# Altium schematic sheet CW308T_PSoC62_UFO.SchDoc
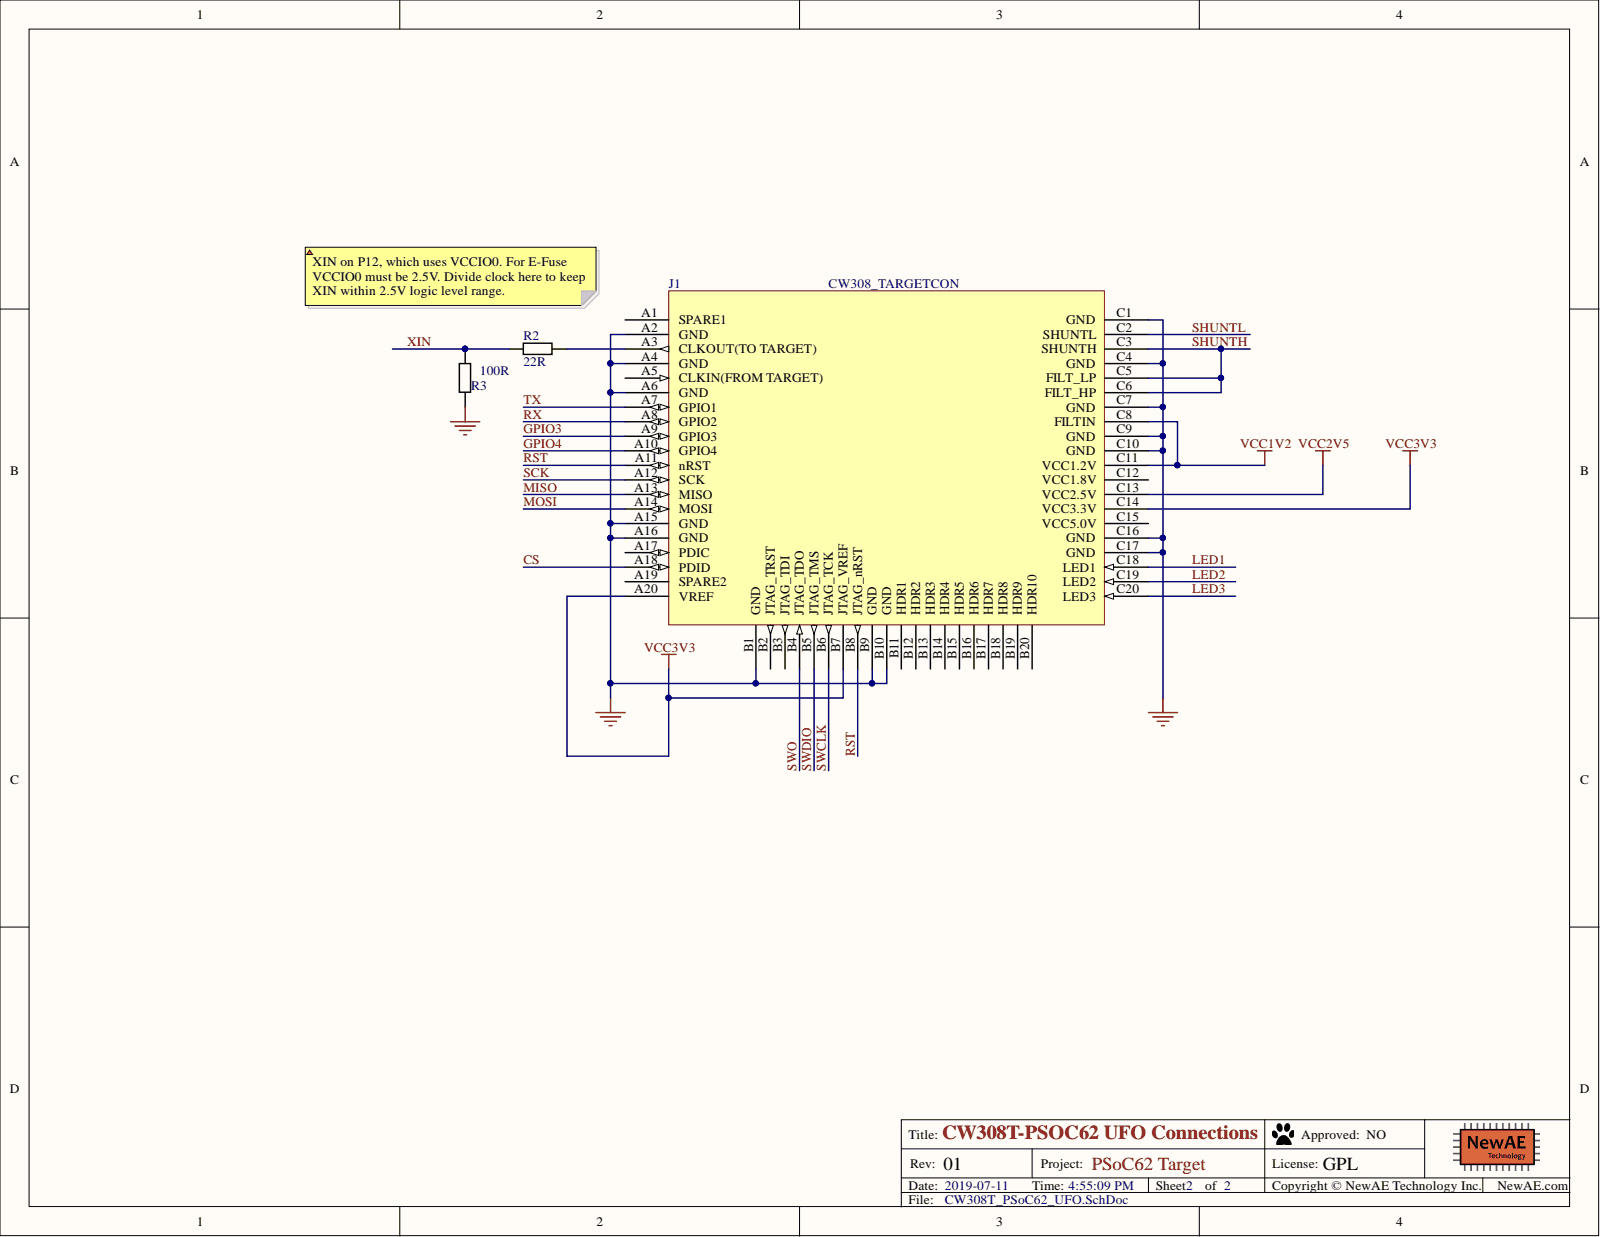
[diagram p0: CW308T_PSoC62_UFO.SchDoc]

## Parts
J1  CW308_TARGETCON  [CW308_TBOARD_CONN]
R2  22R  [0603]
R3  100R  [0603]

## Nets
GND: J1.A2(GND) J1.A4(GND) J1.A6(GND) J1.A15(GND) J1.A16(GND) J1.B1(GND) J1.B9(GND) J1.B10(GND) J1.C1(GND) J1.C4(GND) J1.C7(GND) J1.C9(GND) J1.C10(GND) J1.C16(GND) J1.C17(GND) R3.1
VCC1V2: J1.C8(FILTIN) J1.C11(VCC1.2V)
VCC2V5: J1.C13(VCC2.5V)
VCC3V3: J1.A20(VREF) J1.B7(JTAG_VREF) J1.C14(VCC3.3V)
CS: J1.A18(PDID)
GPIO3: J1.A9(GPIO3)
GPIO4: J1.A10(GPIO4)
LED1: J1.C18(LED1)
LED2: J1.C19(LED2)
LED3: J1.C20(LED3)
MISO: J1.A13(MISO)
MOSI: J1.A14(MOSI)
RST: J1.A11(nRST) J1.B8(JTAG_nRST)
RX: J1.A8(GPIO2)
SCK: J1.A12(SCK)
SHUNTH: J1.C3(SHUNTH) J1.C5(FILT_LP) J1.C6(FILT_HP)
SHUNTL: J1.C2(SHUNTL)
SWCLK: J1.B6(JTAG_TCK)
SWDIO: J1.B5(JTAG_TMS)
SWO: J1.B4(JTAG_TDO)
TX: J1.A7(GPIO1)
XIN: R2.1 R3.2
NetJ1_A3: J1.A3(CLKOUT(TO_TARGET)) R2.2

Not connected: J1 A1(SPARE1) A5(CLKIN(FROM_TARGET)) A17(PDIC) A19(SPARE2) B2(JTAG_TRST) B3(JTAG_TDI) B11(HDR1) B12(HDR2) B13(HDR3) B14(HDR4) B15(HDR5) B16(HDR6) B17(HDR7) B18(HDR8) B19(HDR9) B20(HDR10) C12(VCC1.8V) C15(VCC5.0V)

## Library data of the parts
J1 = CW308_TBOARD: CW308 "Standard" Target Board Connector; Component Group: Mechanical; Database Table Name: main; Pitch: 2.54mm; Component Kind: Standard; Component Type: Standard; Library Name: NewAE_AltiumLib.DBLib; Mounting Technology: Through-hole; Part Number: CW308_TBOARD; Pin Count: 60
R2 = RCHIP-22R-0603: 22R res, 0603, 1%, 1/10W; Manufacture 1: Yageo; Manufacture Part Number 1: RC0603FR-0722RL; Case-Metric: 1608; Component Group: Resistor; Database Table Name: main; Rated Power: 0.1W; Rated Voltage: 75VDC; Case-EIA: 0603; Component Kind: Standard; Component Type: Standard; Library Name: NewAE_AltiumLib.DBLib; Mounting Technology: SMT; Part Number: RCHIP-22R-0603; Pin Count: 2; Supplier 1: Digi-Key; Supplier Part Number 1: 311-22.0HRCT-ND; Temperature Range: -55C to 155C; Tolerance: 1%; Value: 22R
R3 = RCHIP-100R-0603: 100R res, 0603, 1%, 1/10W; Manufacture 1: Yageo; Manufacture Part Number 1: RC0603FR-07100RL; Case-Metric: 1608; Component Group: Resistor; Database Table Name: main; Rated Power: 0.1W; Rated Voltage: 75VDC; Case-EIA: 0603; Component Kind: Standard; Component Type: Standard; Library Name: NewAE_AltiumLib.DBLib; Mounting Technology: SMT; Part Number: RCHIP-100R-0603; Pin Count: 2; Supplier 1: Digi-Key; Supplier Part Number 1: 311-100HRCT-ND; Temperature Range: -55C to 155C; Tolerance: 1%; Value: 100R
